# Altium schematic sheet ZYNQ_DDR3.SchDoc(ZYNQ_DDR3)
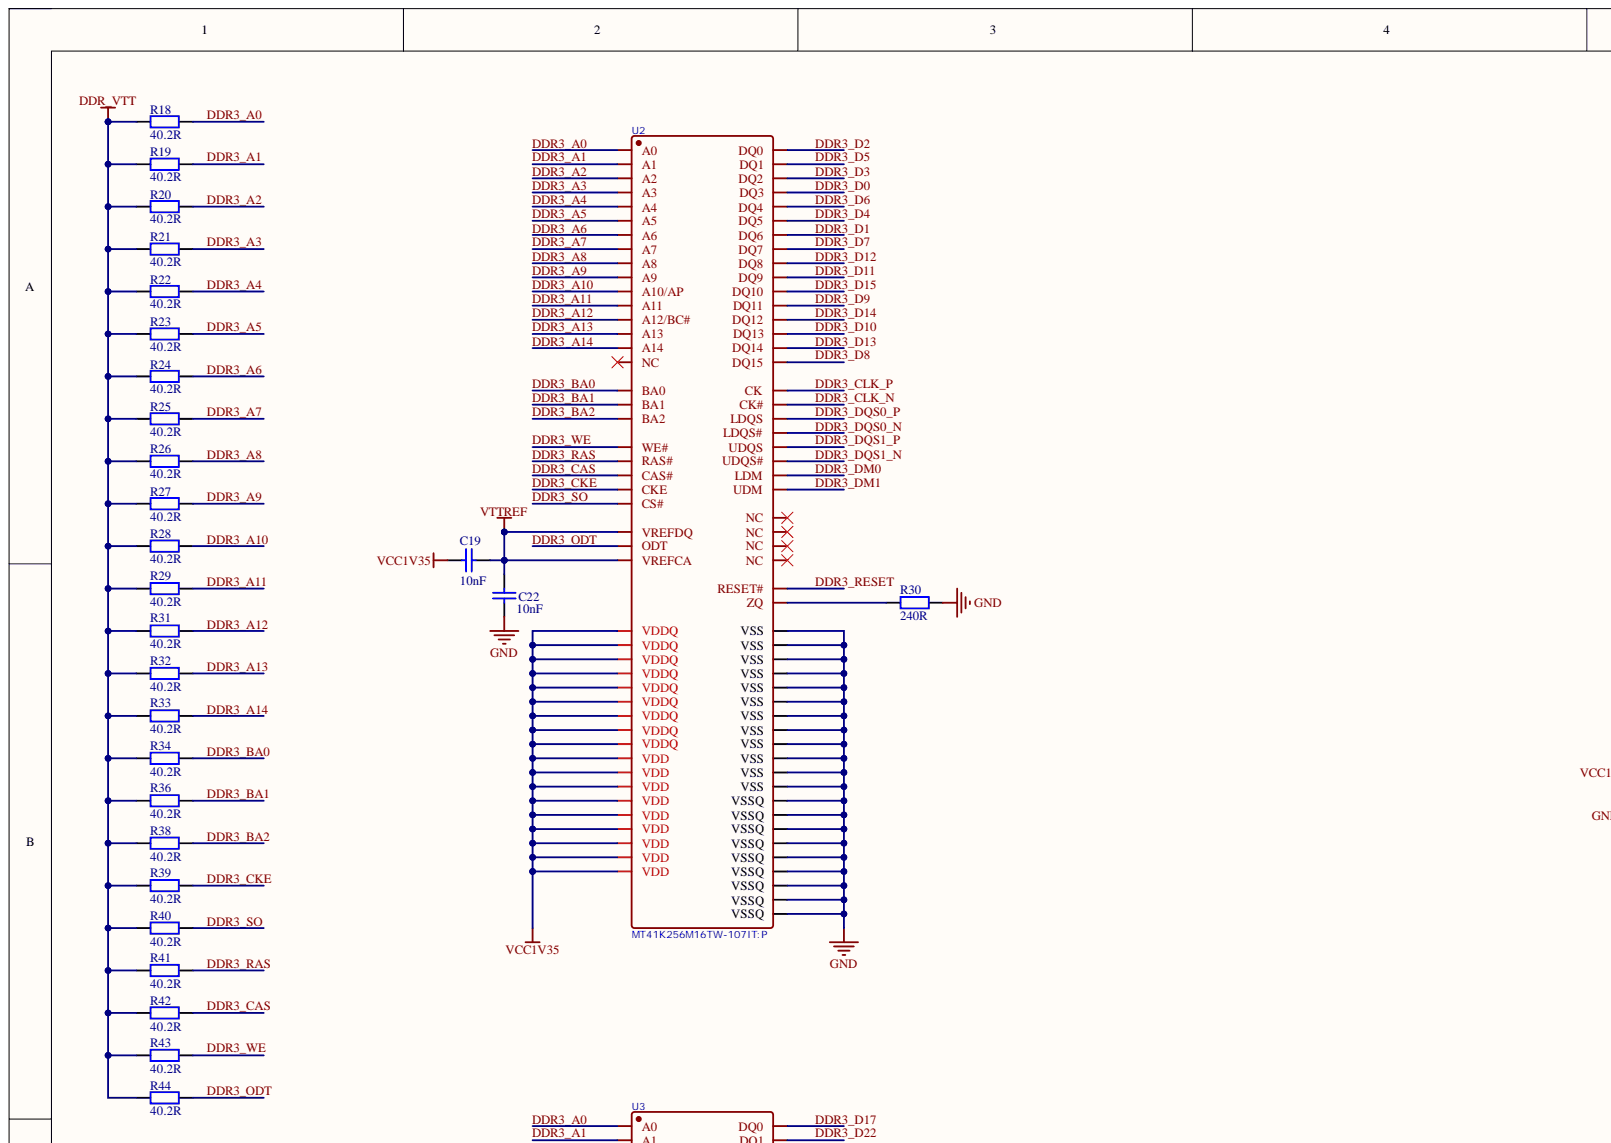
[diagram p0: ZYNQ_DDR3.SchDoc, top left]
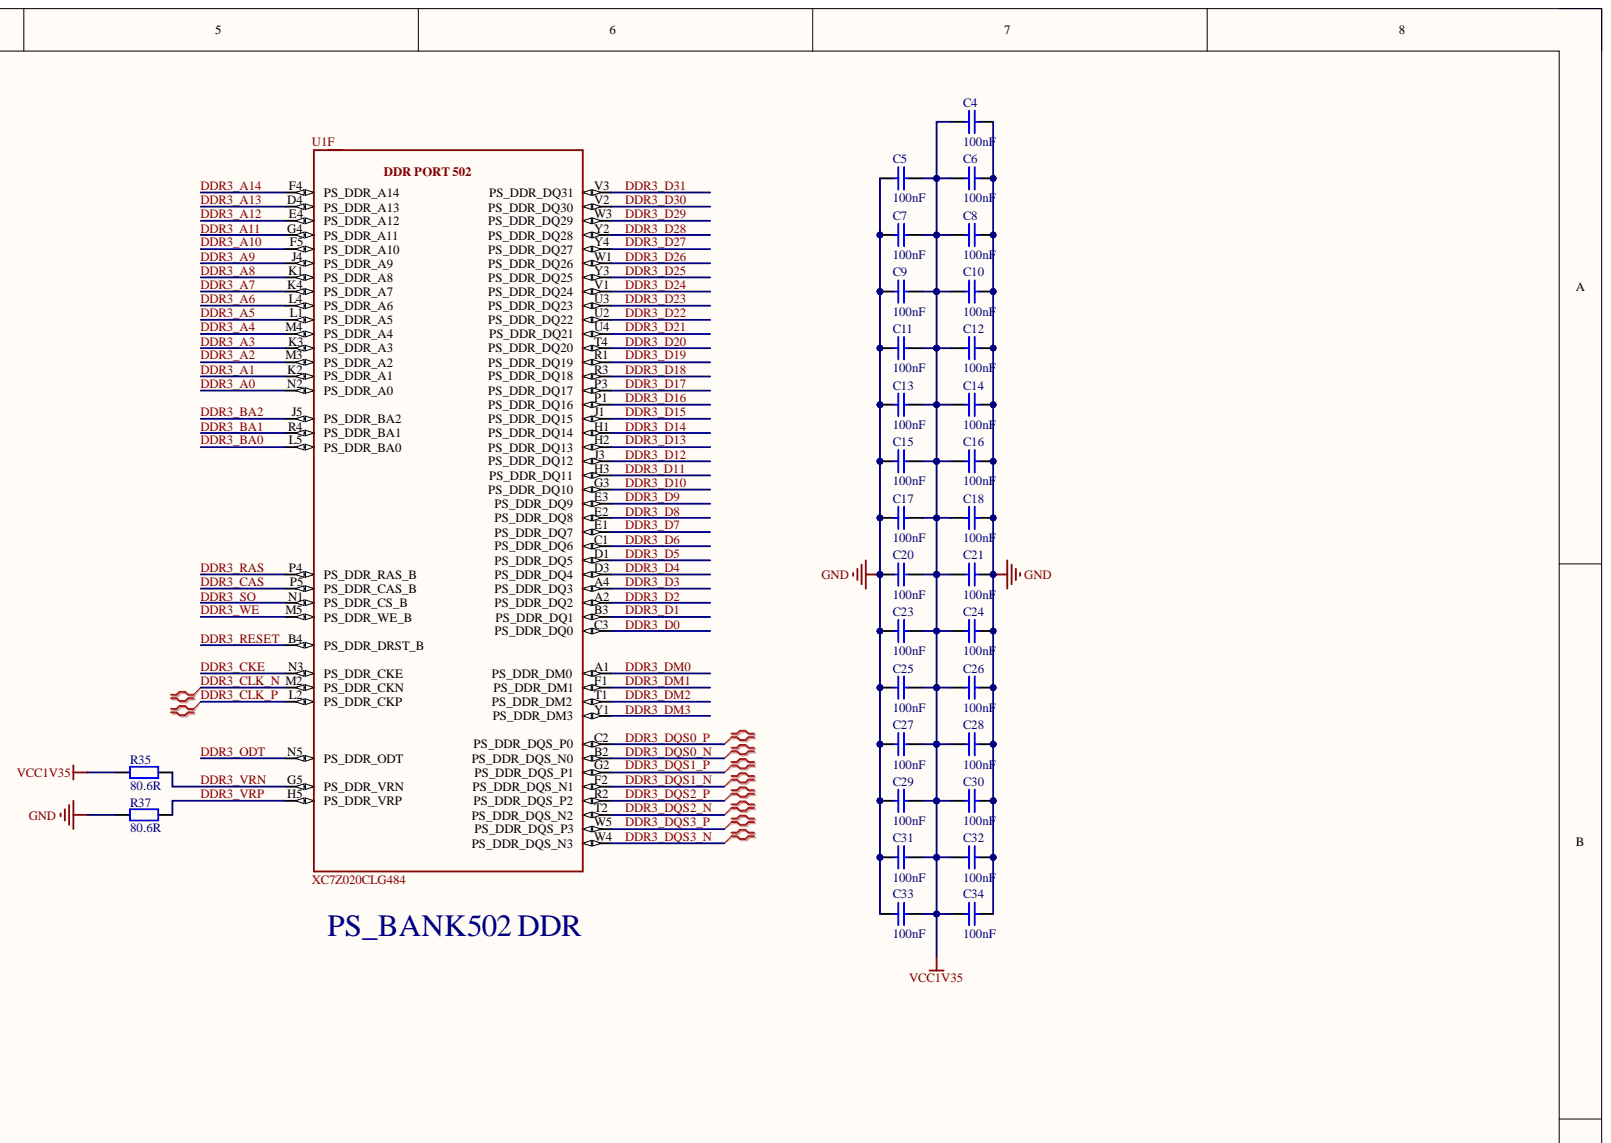
[diagram p1: ZYNQ_DDR3.SchDoc, top right]
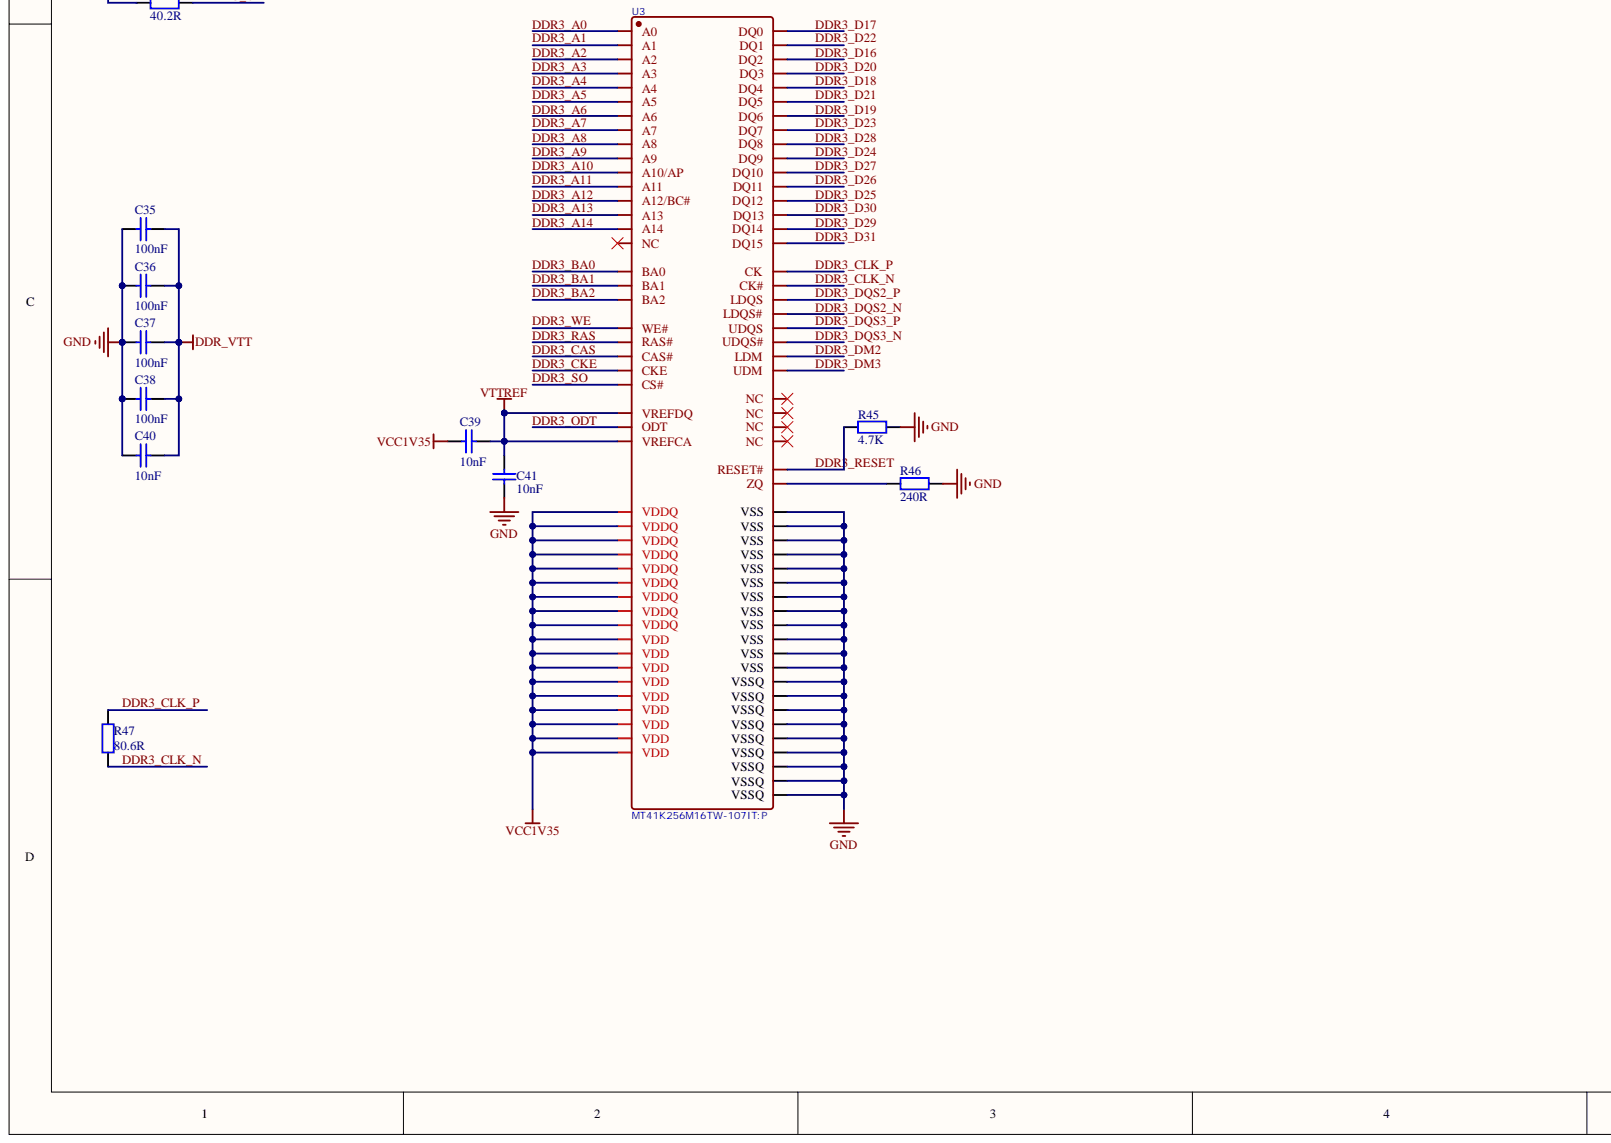
[diagram p2: ZYNQ_DDR3.SchDoc, bottom left]
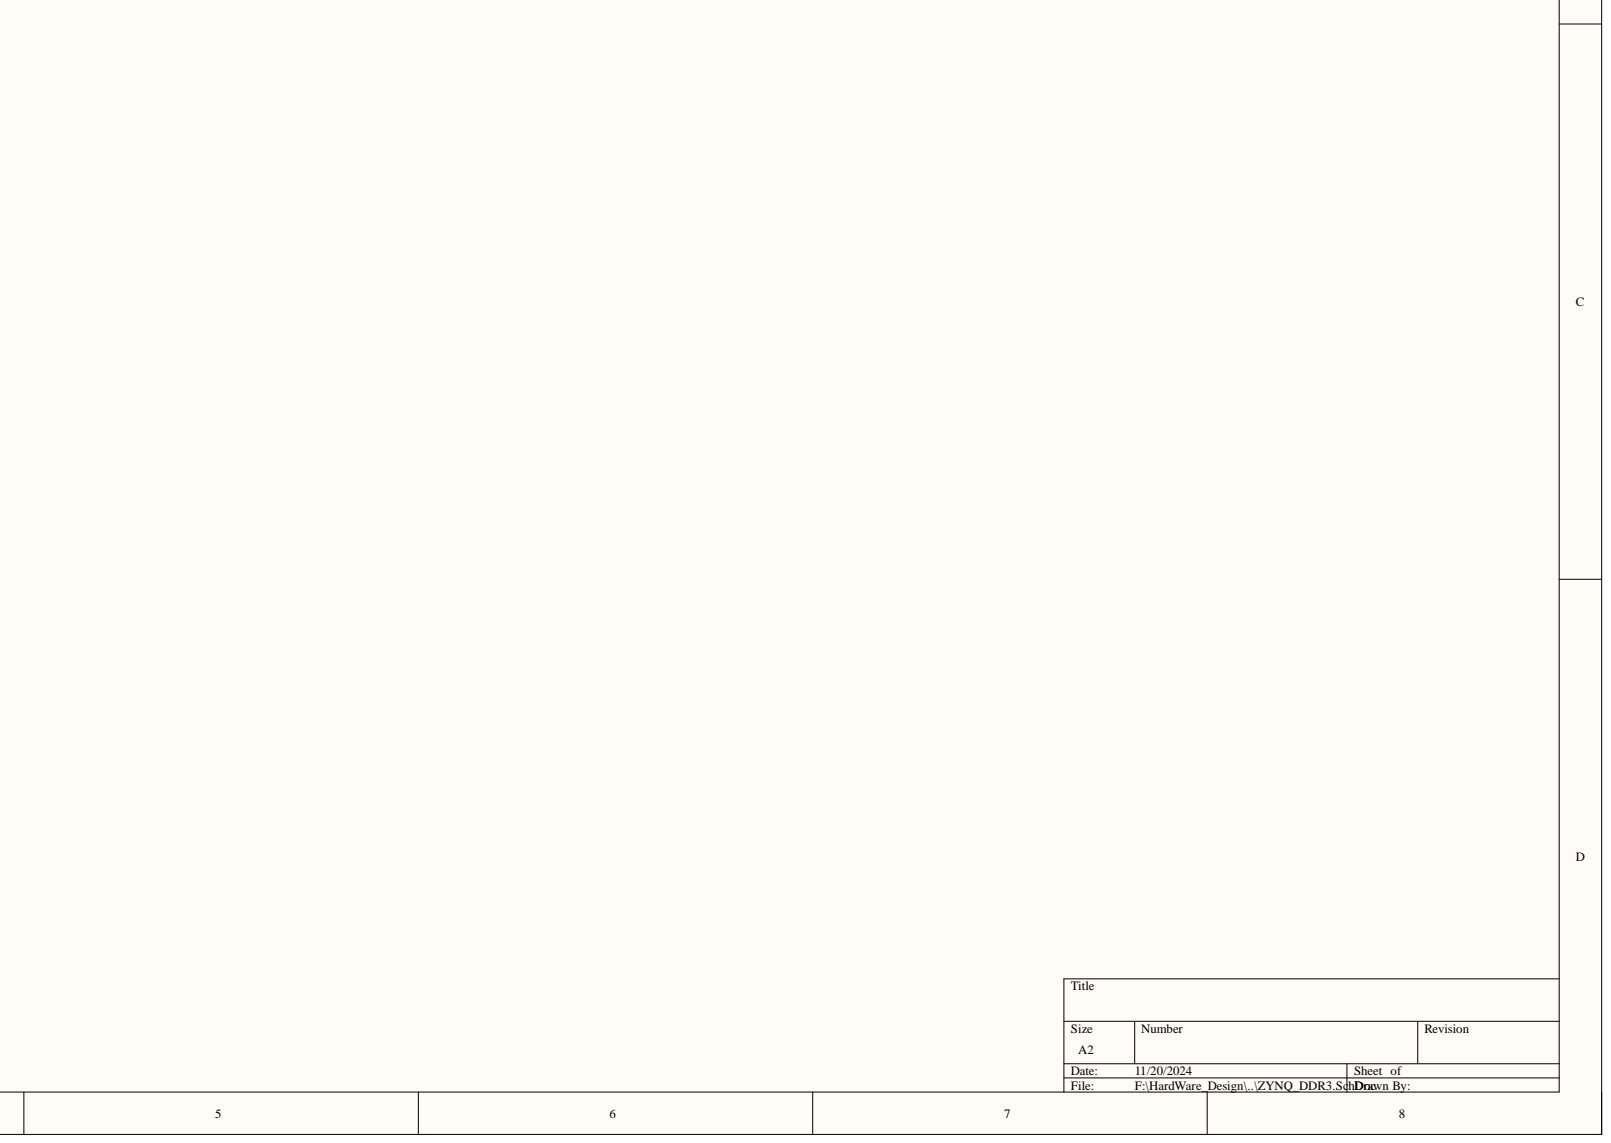
[diagram p3: ZYNQ_DDR3.SchDoc, bottom right]

## Parts
C4  100nF  [C 0402_L]
C5  100nF  [C 0402_L]
C6  100nF  [C 0402_L]
C7  100nF  [C 0402_L]
C8  100nF  [C 0402_L]
C9  100nF  [C 0402_L]
C10  100nF  [C 0402_L]
C11  100nF  [C 0402_L]
C12  100nF  [C 0402_L]
C13  100nF  [C 0402_L]
C14  100nF  [C 0402_L]
C15  100nF  [C 0402_L]
C16  100nF  [C 0402_L]
C17  100nF  [C 0402_L]
C18  100nF  [C 0402_L]
C19  10nF  [C 0402_L]
C20  100nF  [C 0402_L]
C21  100nF  [C 0402_L]
C22  10nF  [C 0402_L]
C23  100nF  [C 0402_L]
C24  100nF  [C 0402_L]
C25  100nF  [C 0402_L]
C26  100nF  [C 0402_L]
C27  100nF  [C 0402_L]
C28  100nF  [C 0402_L]
C29  100nF  [C 0402_L]
C30  100nF  [C 0402_L]
C31  100nF  [C 0402_L]
C32  100nF  [C 0402_L]
C33  100nF  [C 0402_L]
C34  100nF  [C 0402_L]
C35  100nF  [C 0402_L]
C36  100nF  [C 0402_L]
C37  100nF  [C 0402_L]
C38  100nF  [C 0402_L]
C39  10nF  [C 0402_L]
C40  100nF  value 10nF  [C 0402_L]
C41  10nF  [C 0402_L]
R18  40.2R  [R 0402_L]
R19  40.2R  [R 0402_L]
R20  40.2R  [R 0402_L]
R21  40.2R  [R 0402_L]
R22  40.2R  [R 0402_L]
R23  40.2R  [R 0402_L]
R24  40.2R  [R 0402_L]
R25  40.2R  [R 0402_L]
R26  40.2R  [R 0402_L]
R27  40.2R  [R 0402_L]
R28  40.2R  [R 0402_L]
R29  40.2R  [R 0402_L]
R30  240R  [R 0402_L]
R31  40.2R  [R 0402_L]
R32  40.2R  [R 0402_L]
R33  40.2R  [R 0402_L]
R34  40.2R  [R 0402_L]
R35  80.6R  [R 0402_L]
R36  40.2R  [R 0402_L]
R37  80.6R  [R 0402_L]
R38  40.2R  [R 0402_L]
R39  40.2R  [R 0402_L]
R40  40.2R  [R 0402_L]
R41  40.2R  [R 0402_L]
R42  40.2R  [R 0402_L]
R43  40.2R  [R 0402_L]
R44  40.2R  [R 0402_L]
R45  4.7K  [R 0402_L]
R46  240R  [R 0402_L]
R47  80.6R  [R 0402_L]
U1  XC7Z020CLG484  [BGA400C80P20X20_1700X1700X160]  (part F)
U2  MT41K256M16TW-107IT:P  [MICT-JT-96]
U3  MT41K256M16TW-107IT:P  [MICT-JT-96]

## Nets
DDR_VTT: C35.1 C36.1 C37.1 C38.1 C40.1 R18.1 R19.1 R20.1 R21.1 R22.1 R23.1 R24.1 R25.1 R26.1 R27.1 R28.1 R29.1 R31.1 R32.1 R33.1 R34.1 R36.1 R38.1 R39.1 R40.1 R41.1 R42.1 R43.1 R44.1
GND: C4.1 C5.2 C6.1 C7.2 C8.1 C9.2 C10.1 C11.2 C12.1 C13.2 C14.1 C15.2 C16.1 C17.2 C18.1 C20.2 C21.1 C22.2 C23.2 C24.1 C25.2 C26.1 C27.2 C28.1 C29.2 C30.1 C31.2 C32.1 C33.2 C34.1 C35.2 C36.2 C37.2 C38.2 C40.2 C41.2 R30.2 R37.1 R45.2 R46.2 U2.A9(VSS) U2.B1(VSSQ) U2.B3(VSS) U2.B9(VSSQ) U2.D1(VSSQ) U2.D8(VSSQ) U2.E1(VSS) U2.E2(VSSQ) U2.E8(VSSQ) U2.F9(VSSQ) U2.G1(VSSQ) U2.G8(VSS) U2.G9(VSSQ) U2.J2(VSS) U2.J8(VSS) U2.M1(VSS) U2.M9(VSS) U2.P1(VSS) U2.P9(VSS) U2.T1(VSS) U2.T9(VSS) U3.A9(VSS) U3.B1(VSSQ) U3.B3(VSS) U3.B9(VSSQ) U3.D1(VSSQ) U3.D8(VSSQ) U3.E1(VSS) U3.E2(VSSQ) U3.E8(VSSQ) U3.F9(VSSQ) U3.G1(VSSQ) U3.G8(VSS) U3.G9(VSSQ) U3.J2(VSS) U3.J8(VSS) U3.M1(VSS) U3.M9(VSS) U3.P1(VSS) U3.P9(VSS) U3.T1(VSS) U3.T9(VSS)
VCC1V35: C4.2 C5.1 C6.2 C7.1 C8.2 C9.1 C10.2 C11.1 C12.2 C13.1 C14.2 C15.1 C16.2 C17.1 C18.2 C19.2 C20.1 C21.2 C23.1 C24.2 C25.1 C26.2 C27.1 C28.2 C29.1 C30.2 C31.1 C32.2 C33.1 C34.2 C39.2 R35.1 U2.A1(VDDQ) U2.A8(VDDQ) U2.B2(VDD) U2.C1(VDDQ) U2.C9(VDDQ) U2.D2(VDDQ) U2.D9(VDD) U2.E9(VDDQ) U2.F1(VDDQ) U2.G7(VDD) U2.H2(VDDQ) U2.H9(VDDQ) U2.K2(VDD) U2.K8(VDD) U2.N1(VDD) U2.N9(VDD) U2.R1(VDD) U2.R9(VDD) U3.A1(VDDQ) U3.A8(VDDQ) U3.B2(VDD) U3.C1(VDDQ) U3.C9(VDDQ) U3.D2(VDDQ) U3.D9(VDD) U3.E9(VDDQ) U3.F1(VDDQ) U3.G7(VDD) U3.H2(VDDQ) U3.H9(VDDQ) U3.K2(VDD) U3.K8(VDD) U3.N1(VDD) U3.N9(VDD) U3.R1(VDD) U3.R9(VDD)
VTTREF: C19.1 C22.1 C39.1 C41.1 U2.H1(VREFDQ) U2.M8(VREFCA) U3.H1(VREFDQ) U3.M8(VREFCA)
DDR3_A0: R18.2 U1.N2(PS_DDR_A0) U2.N3(A0) U3.N3(A0)
DDR3_A1: R19.2 U1.K2(PS_DDR_A1) U2.P7(A1) U3.P7(A1)
DDR3_A2: R20.2 U1.M3(PS_DDR_A2) U2.P3(A2) U3.P3(A2)
DDR3_A3: R21.2 U1.K3(PS_DDR_A3) U2.N2(A3) U3.N2(A3)
DDR3_A4: R22.2 U1.M4(PS_DDR_A4) U2.P8(A4) U3.P8(A4)
DDR3_A5: R23.2 U1.L1(PS_DDR_A5) U2.P2(A5) U3.P2(A5)
DDR3_A6: R24.2 U1.L4(PS_DDR_A6) U2.R8(A6) U3.R8(A6)
DDR3_A7: R25.2 U1.K4(PS_DDR_A7) U2.R2(A7) U3.R2(A7)
DDR3_A8: R26.2 U1.K1(PS_DDR_A8) U2.T8(A8) U3.T8(A8)
DDR3_A9: R27.2 U1.J4(PS_DDR_A9) U2.R3(A9) U3.R3(A9)
DDR3_A10: R28.2 U1.F5(PS_DDR_A10) U2.L7(A10/AP) U3.L7(A10/AP)
DDR3_A11: R29.2 U1.G4(PS_DDR_A11) U2.R7(A11) U3.R7(A11)
DDR3_A12: R31.2 U1.E4(PS_DDR_A12) U2.N7(A12/BC#) U3.N7(A12/BC#)
DDR3_A13: R32.2 U1.D4(PS_DDR_A13) U2.T3(A13) U3.T3(A13)
DDR3_A14: R33.2 U1.F4(PS_DDR_A14) U2.T7(A14) U3.T7(A14)
DDR3_BA0: R34.2 U1.L5(PS_DDR_BA0) U2.M2(BA0) U3.M2(BA0)
DDR3_BA1: R36.2 U1.R4(PS_DDR_BA1) U2.N8(BA1) U3.N8(BA1)
DDR3_BA2: R38.2 U1.J5(PS_DDR_BA2) U2.M3(BA2) U3.M3(BA2)
DDR3_CAS: R42.2 U1.P5(PS_DDR_CAS_B) U2.K3(CAS#) U3.K3(CAS#)
DDR3_CKE: R39.2 U1.N3(PS_DDR_CKE) U2.K9(CKE) U3.K9(CKE)
DDR3_CLK_N: R47.1 U1.M2(PS_DDR_CKN) U2.K7(CK#) U3.K7(CK#)
DDR3_CLK_P: R47.2 U1.L2(PS_DDR_CKP) U2.J7(CK) U3.J7(CK)
DDR3_D0: U1.C3(PS_DDR_DQ0) U2.F8(DQ3)
DDR3_D1: U1.B3(PS_DDR_DQ1) U2.G2(DQ6)
DDR3_D2: U1.A2(PS_DDR_DQ2) U2.E3(DQ0)
DDR3_D3: U1.A4(PS_DDR_DQ3) U2.F2(DQ2)
DDR3_D4: U1.D3(PS_DDR_DQ4) U2.H8(DQ5)
DDR3_D5: U1.D1(PS_DDR_DQ5) U2.F7(DQ1)
DDR3_D6: U1.C1(PS_DDR_DQ6) U2.H3(DQ4)
DDR3_D7: U1.E1(PS_DDR_DQ7) U2.H7(DQ7)
DDR3_D8: U1.E2(PS_DDR_DQ8) U2.A3(DQ15)
DDR3_D9: U1.E3(PS_DDR_DQ9) U2.C2(DQ11)
DDR3_D10: U1.G3(PS_DDR_DQ10) U2.A2(DQ13)
DDR3_D11: U1.H3(PS_DDR_DQ11) U2.C3(DQ9)
DDR3_D12: U1.J3(PS_DDR_DQ12) U2.D7(DQ8)
DDR3_D13: U1.H2(PS_DDR_DQ13) U2.B8(DQ14)
DDR3_D14: U1.H1(PS_DDR_DQ14) U2.A7(DQ12)
DDR3_D15: U1.J1(PS_DDR_DQ15) U2.C8(DQ10)
DDR3_D16: U1.P1(PS_DDR_DQ16) U3.F2(DQ2)
DDR3_D17: U1.P3(PS_DDR_DQ17) U3.E3(DQ0)
DDR3_D18: U1.R3(PS_DDR_DQ18) U3.H3(DQ4)
DDR3_D19: U1.R1(PS_DDR_DQ19) U3.G2(DQ6)
DDR3_D20: U1.T4(PS_DDR_DQ20) U3.F8(DQ3)
DDR3_D21: U1.U4(PS_DDR_DQ21) U3.H8(DQ5)
DDR3_D22: U1.U2(PS_DDR_DQ22) U3.F7(DQ1)
DDR3_D23: U1.U3(PS_DDR_DQ23) U3.H7(DQ7)
DDR3_D24: U1.V1(PS_DDR_DQ24) U3.C3(DQ9)
DDR3_D25: U1.Y3(PS_DDR_DQ25) U3.A7(DQ12)
DDR3_D26: U1.W1(PS_DDR_DQ26) U3.C2(DQ11)
DDR3_D27: U1.Y4(PS_DDR_DQ27) U3.C8(DQ10)
DDR3_D28: U1.Y2(PS_DDR_DQ28) U3.D7(DQ8)
DDR3_D29: U1.W3(PS_DDR_DQ29) U3.B8(DQ14)
DDR3_D30: U1.V2(PS_DDR_DQ30) U3.A2(DQ13)
DDR3_D31: U1.V3(PS_DDR_DQ31) U3.A3(DQ15)
DDR3_DM0: U1.A1(PS_DDR_DM0) U2.E7(LDM)
DDR3_DM1: U1.F1(PS_DDR_DM1) U2.D3(UDM)
DDR3_DM2: U1.T1(PS_DDR_DM2) U3.E7(LDM)
DDR3_DM3: U1.Y1(PS_DDR_DM3) U3.D3(UDM)
DDR3_DQS0_N: U1.B2(PS_DDR_DQS_N0) U2.G3(LDQS#)
DDR3_DQS0_P: U1.C2(PS_DDR_DQS_P0) U2.F3(LDQS)
DDR3_DQS1_N: U1.F2(PS_DDR_DQS_N1) U2.B7(UDQS#)
DDR3_DQS1_P: U1.G2(PS_DDR_DQS_P1) U2.C7(UDQS)
DDR3_DQS2_N: U1.T2(PS_DDR_DQS_N2) U3.G3(LDQS#)
DDR3_DQS2_P: U1.R2(PS_DDR_DQS_P2) U3.F3(LDQS)
DDR3_DQS3_N: U1.W4(PS_DDR_DQS_N3) U3.B7(UDQS#)
DDR3_DQS3_P: U1.W5(PS_DDR_DQS_P3) U3.C7(UDQS)
DDR3_ODT: R44.2 U1.N5(PS_DDR_ODT) U2.K1(ODT) U3.K1(ODT)
DDR3_RAS: R41.2 U1.P4(PS_DDR_RAS_B) U2.J3(RAS#) U3.J3(RAS#)
DDR3_RESET: R45.1 U1.B4(PS_DDR_DRST_B) U2.T2(RESET#) U3.T2(RESET#)
DDR3_SO: R40.2 U1.N1(PS_DDR_CS_B) U2.L2(CS#) U3.L2(CS#)
DDR3_VRN: R35.2 U1.G5(PS_DDR_VRN)
DDR3_VRP: R37.2 U1.H5(PS_DDR_VRP)
DDR3_WE: R43.2 U1.M5(PS_DDR_WE_B) U2.L3(WE#) U3.L3(WE#)
NetR30_1: R30.1 U2.L8(ZQ)
NetR46_1: R46.1 U3.L8(ZQ)

Not connected: U2 J1(NC) J9(NC) L1(NC) L9(NC) M7(NC); U3 J1(NC) J9(NC) L1(NC) L9(NC) M7(NC)

## Library data of the parts
C4-C41 = Cap1: Component Kind: Standard
  C4-C18 C20 C21 C23-C38: value: 100nF
  C19 C22 C39 C41: value: 10nF; Library Name: 零散元件-V1.1.SCHLIB
  C4-C18 C20 C21 C23-C38 C40: Library Name: 零散元件库.SCHLIB
R18-R47 = Res: Component Kind: Standard; Library Name: 零散元件库1.SCHLIB
  R18-R29 R31-R34 R36 R38-R44: value: 40.2R
  R30 R46: value: 240R
  R35 R37 R47: value: 80.6R
  R45: value: 4.7K
U1 = XC7Z020: Zynq 7000 FPGA 20 Die, in a CSG 484 Package; Manufacturer: Xilinx; Component Kind: Standard; Library Name: ZYNQ_PCB.SCHLIB
U2 U3 = MT41K256M16TW-107IT:P: Component Kind: Standard; Library Name: A_Schlib.SchLib
